# Altium schematic sheet CuC_ControlComm.SchDoc
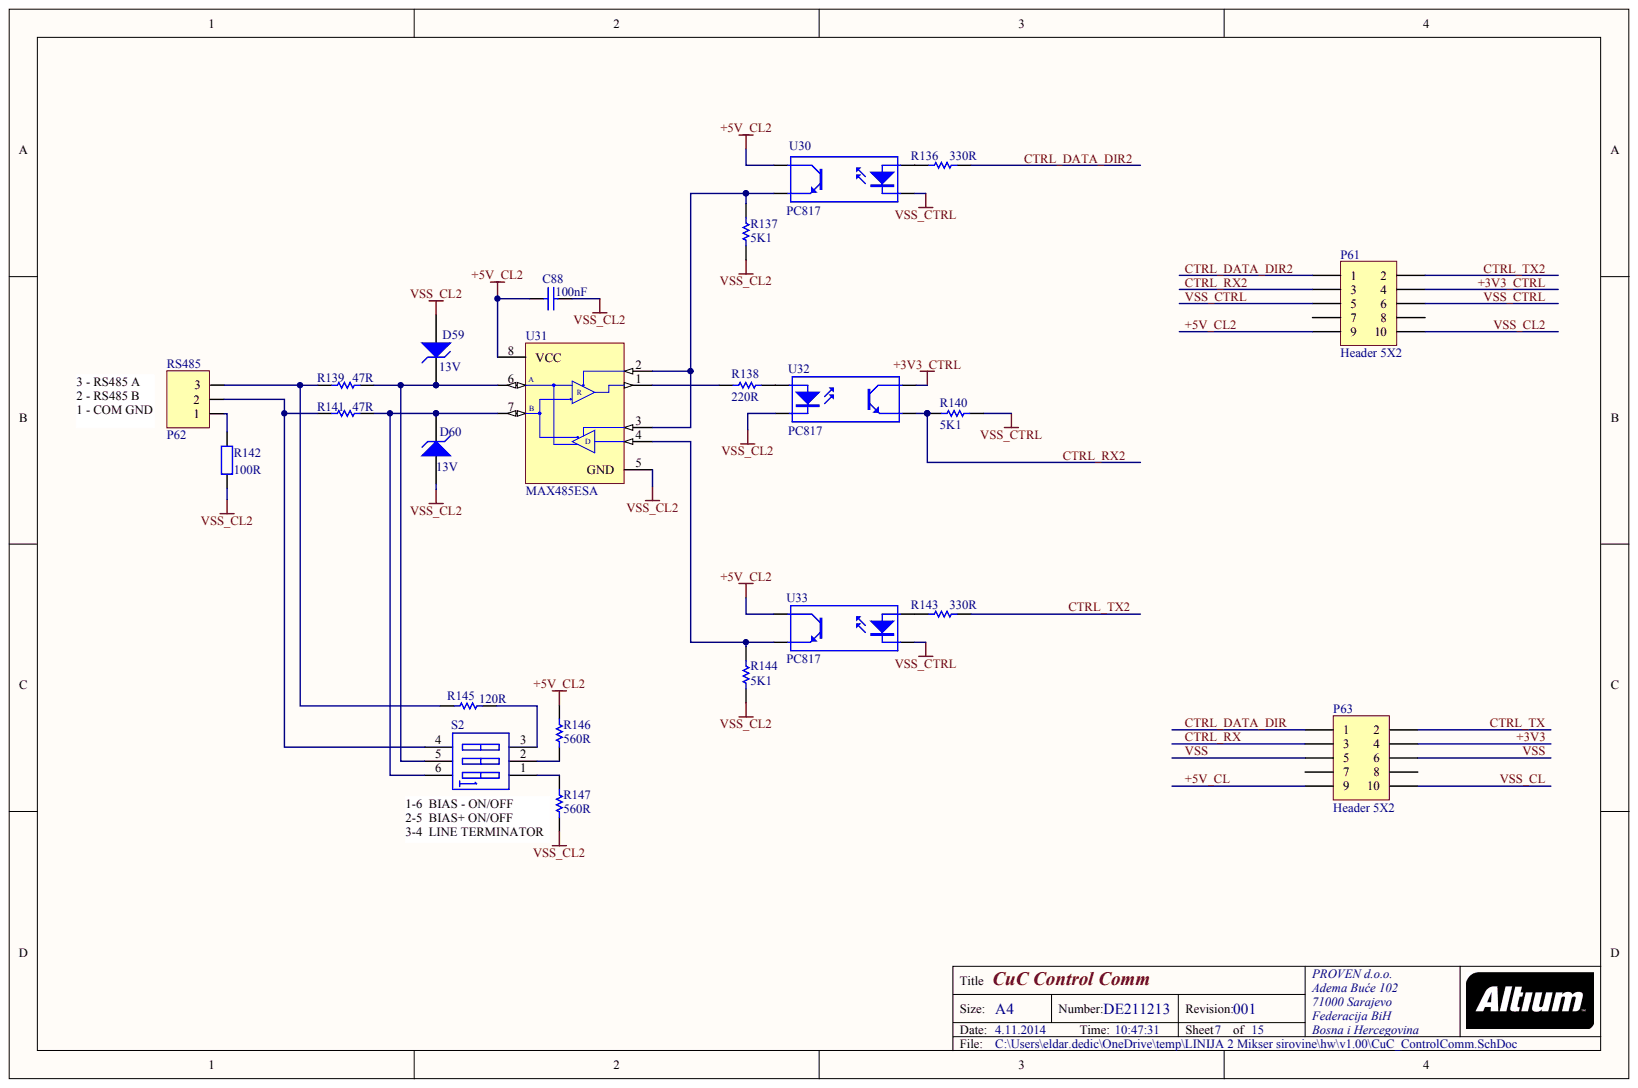
[diagram p0: CuC_ControlComm.SchDoc]

## Parts
C88  100nF
D59  13V
D60  13V
P61  Header 5X2
P62  RS485
P63  Header 5X2
R136  330R
R137  5K1
R138  220R
R139  47R
R140  5K1
R141  47R
R142  100R
R143  330R
R144  5K1
R145  120R
R146  560R
R147  560R
S2  (no value)
U30  PC817
U31  MAX485ESA
U32  PC817
U33  PC817

## Nets
+3V3: P63.4
+3V3_CTRL: P61.4 U32.4
+5V_CL: P63.9
+5V_CL2: C88.2 P61.9 R146.2 U30.4 U31.8(VCC) U33.4
CTRL_DATA_DIR: P63.1
CTRL_DATA_DIR2: P61.1 R136.2
CTRL_RX: P63.3
CTRL_RX2: P61.3 R140.1 U32.3
CTRL_TX: P63.2
CTRL_TX2: P61.2 R143.2
VSS: P63.5 P63.6
VSS_CL: P63.10
VSS_CL2: C88.1 D59.1 D60.1 P61.10 R137.1 R142.1 R144.1 R147.1 U31.5(GND) U32.2
VSS_CTRL: P61.5 P61.6 R140.2 U30.2 U33.2
NetD59_2: D59.2 R139.2 S2.5 U31.6
NetD60_2: D60.2 R141.2 S2.6 U31.7
NetP62_1: P62.1 R142.2
NetP62_2: P62.2 R141.1 S2.4
NetP62_3: P62.3 R139.1 R145.1
NetR136_1: R136.1 U30.1
NetR137_2: R137.2 U30.3 U31.2 U31.3
NetR138_1: R138.1 U32.1
NetR138_2: R138.2 U31.1
NetR143_1: R143.1 U33.1
NetR144_2: R144.2 U31.4 U33.3
NetR145_2: R145.2 S2.3
NetR146_1: R146.1 S2.2
NetR147_2: R147.2 S2.1

Not connected: P61 7 8; P63 7 8

## Library data of the parts
R136-R141 R143-R147 = (no symbol name): Note: If flow soldering, adjust pad width of PCB Footprint to 0.7 - 0.8 times the width of the resistor.; Published: 17-Dec-2003; LatestRevisionDate: 19-Mar-2004; LatestRevisionNote: Silkscreen size reduced.; PackageDocument: Mar-2003; ComponentLink1Description: Manufacturer Link; ComponentLink1URL: http://www.panasonic.com/industrial/components/resistive_products.htm; ComponentLink2Description: Datasheet; Publisher: Altium Limited
  R136 R143: PackageReference: ERA-0805; Code_IPC: 2012 [0805]; ComponentLink2URL: http://www.panasonic.com/industrial/components/pdf/AOA0000CE8.pdf
  R137 R140 R144: PackageReference: 8-1206; Code_IPC: 3216 [1206]; ComponentLink2URL: http://www.panasonic.com/industrial/components/pdf/AOA0000CE1.pdf
  R138 R139 R141 R145-R147: PackageReference: ERA-0805; Code_IPC: 2012 [0805]; ComponentLink2URL: http://www.panasonic.com/industrial/components/pdf/AOF0000CE1.pdf
C88 D59 D60 P61-P63 R142 S2 U30-U33 = (no symbol name)
  C88: Published: 17-Jul-2002; LatestRevisionDate: 19-Mar-2004; LatestRevisionNote: Silkscreen size reduced.; DatasheetDocument: Mar-2001; PackageDocument: Mar-2001; PackageReference: 1206; Code_JEITA: 1206; Code_IPC: 3216 [1206]; ComponentLink1Description: Manufacturer Link; ComponentLink1URL: http://www.kemet.com/; ComponentLink2Description: Datasheet; ComponentLink2URL: http://www.kemet.com/kemet/web/homepage/kechome.nsf/vapubfilesname/F3102TA.pdf/$file/F3102TA.pdf
  D59 D60: Published: 8-Jun-2000; LatestRevisionDate: 17-Jul-2002; LatestRevisionNote: Re-released for DXP Platform.; PackageReference: DIODE-0.7; PackageDescription: Diode, Thru-Hole; 2 Leads; 0.7 in Pin Spacing
  P61 P63: LatestRevisionDate: 17-Jul-2002; LatestRevisionNote: Re-released for DXP Platform.
  R142: Published: 8-Jun-2000; LatestRevisionDate: 17-Jul-2002; LatestRevisionNote: Re-released for DXP Platform.; PackageReference: AXIAL-0.4; PackageDescription: Axial Device, Thru-Hole; 2 Leads; 0.4 in Pin Spacing
  S2: Published: 24-Mar-1999; LatestRevisionDate: 17-Jul-2002; LatestRevisionNote: Re-released for DXP Platform.; PackageReference: DIP-6; Code_JEDEC: MO-001-AM
  U30 U32 U33: Published: 24-Mar-1999; LatestRevisionDate: 04-Mar-2005; LatestRevisionNote: Sch pins 3 & 4 Swapped.; DatasheetVersion: Nov-2003; PackageReference: DIP-4
  U31: Published: 16-Jan-2001; LatestRevisionDate: 13-Apr-2006; LatestRevisionNote: IPC-7351 Footprint Added.; DatasheetVersion: Feb-1996; PackageReference: NSO8; PackageDescription: 8-Pin Small Outline Integrated Circuit 1.27 mm Pitch; Code_JEDEC: MS-012-AA; Code_IPC: SOIC127P600-8; ComponentLink1Description: Manufacturer Link; ComponentLink1URL: http://www.maxim-ic.com/; ComponentLink2Description: Datasheet; ComponentLink2URL: http://pdfserv.maxim-ic.com/en/ds/MAX1487-MAX491.pdf
  C88 D59 D60 P61 P63 R142 S2 U30-U33: Publisher: Altium Limited
